# Altium schematic sheet Sch-QWA59_LEDs.SchDoc
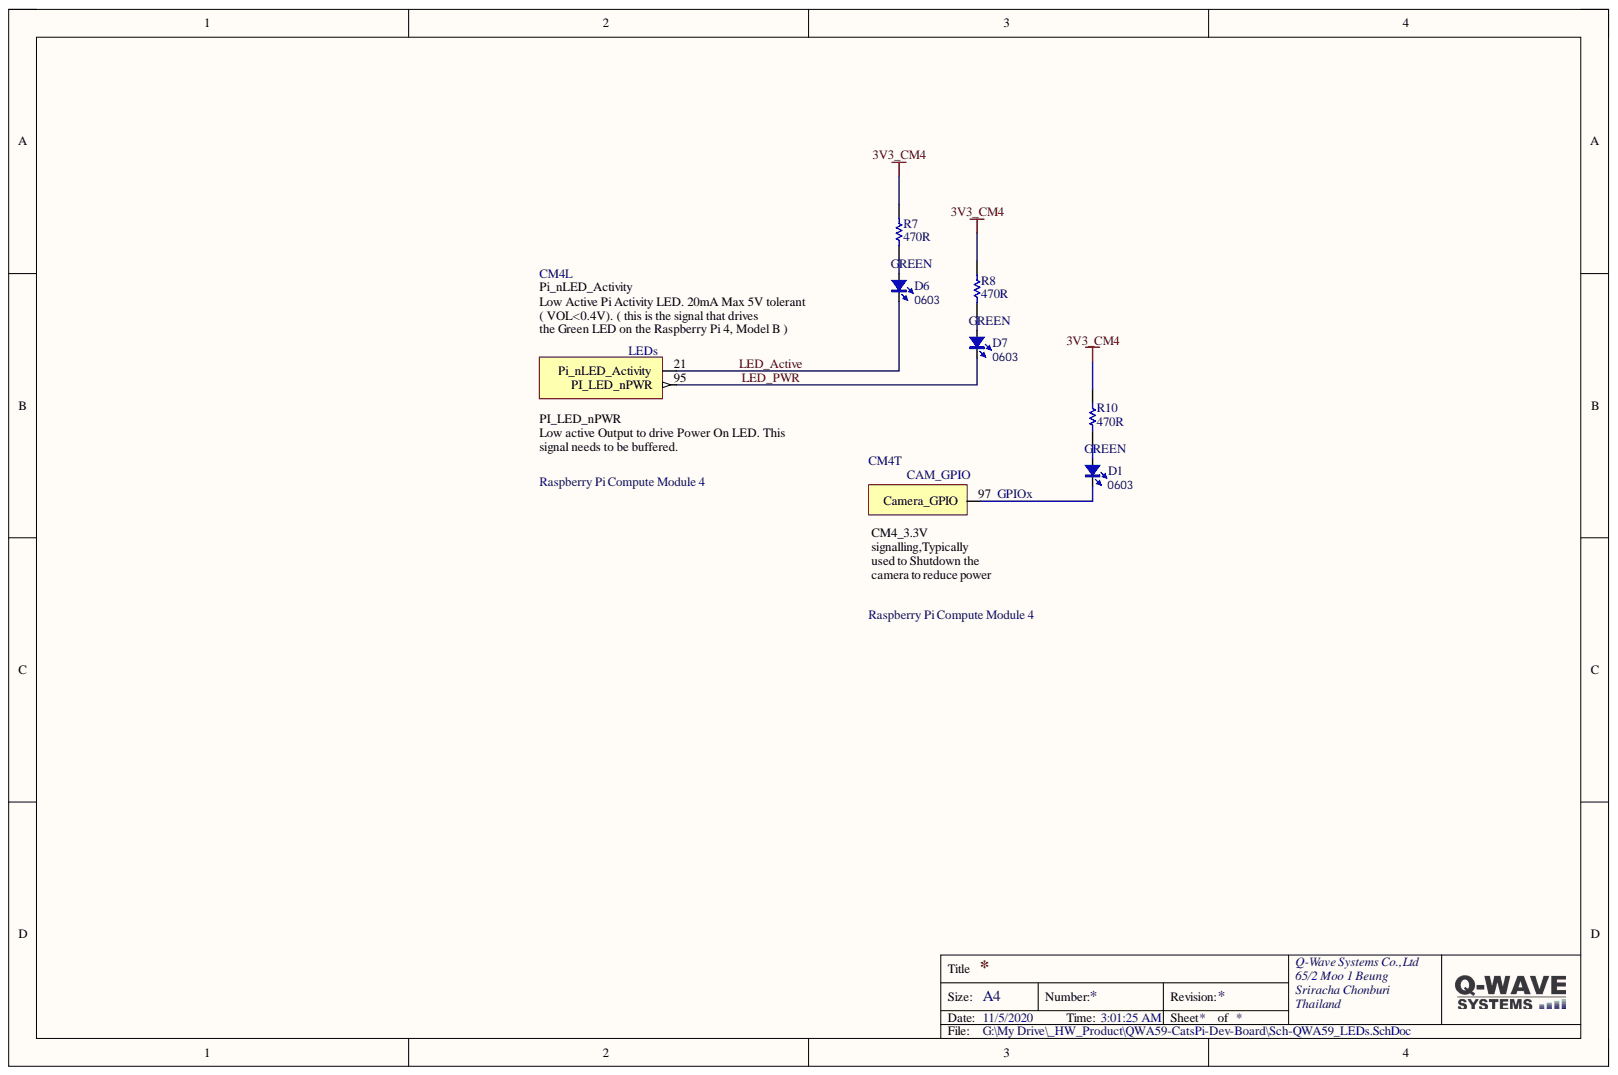
[diagram p0: Sch-QWA59_LEDs.SchDoc]

## Parts
CM4  Raspberry Pi Compute Module 4  [CM4-F40C-100DS-0.4v_1.5mm_0mm]  (parts L,T)
D1  GREEN  Type: 0603  [LED_0603_BLUE]
D6  GREEN  Type: 0603  [LED_0603_BLUE]
D7  GREEN  Type: 0603  [LED_0603_BLUE]
R7  470R  [RES_0603]
R8  470R  [RES_0603]
R10  470R  [RES_0603]

## Nets
3V3_CM4: R7.2 R8.2 R10.2
LED_Active: CM4.21 D6.2
LED_PWR: CM4.95 D7.2
NetCM4_97: CM4.97(Camera_GPIO) D1.2
NetD1_1: D1.1 R10.1
NetD6_1: D6.1 R7.1
NetD7_1: D7.1 R8.1

## Library data of the parts
CM4 = CM4: Raspberry Pi Compute Module 4 by Amornthep Phunsin; Component Kind: Standard; Library Name: CM4.SchLib
D1 D6 D7 = LED_0603: LED; Value: color?; Component Kind: Standard; Library Name: SCH_Active.SchLib
R7 R8 R10 = Res Semi: 470R Resistor 0603; Component Kind: Standard; Library Name: SCH_Passive.SchLib; Signal Integrity: Res Semi; Simulation: RES
